# Altium schematic sheet Schematic.SchDoc(Schematic)
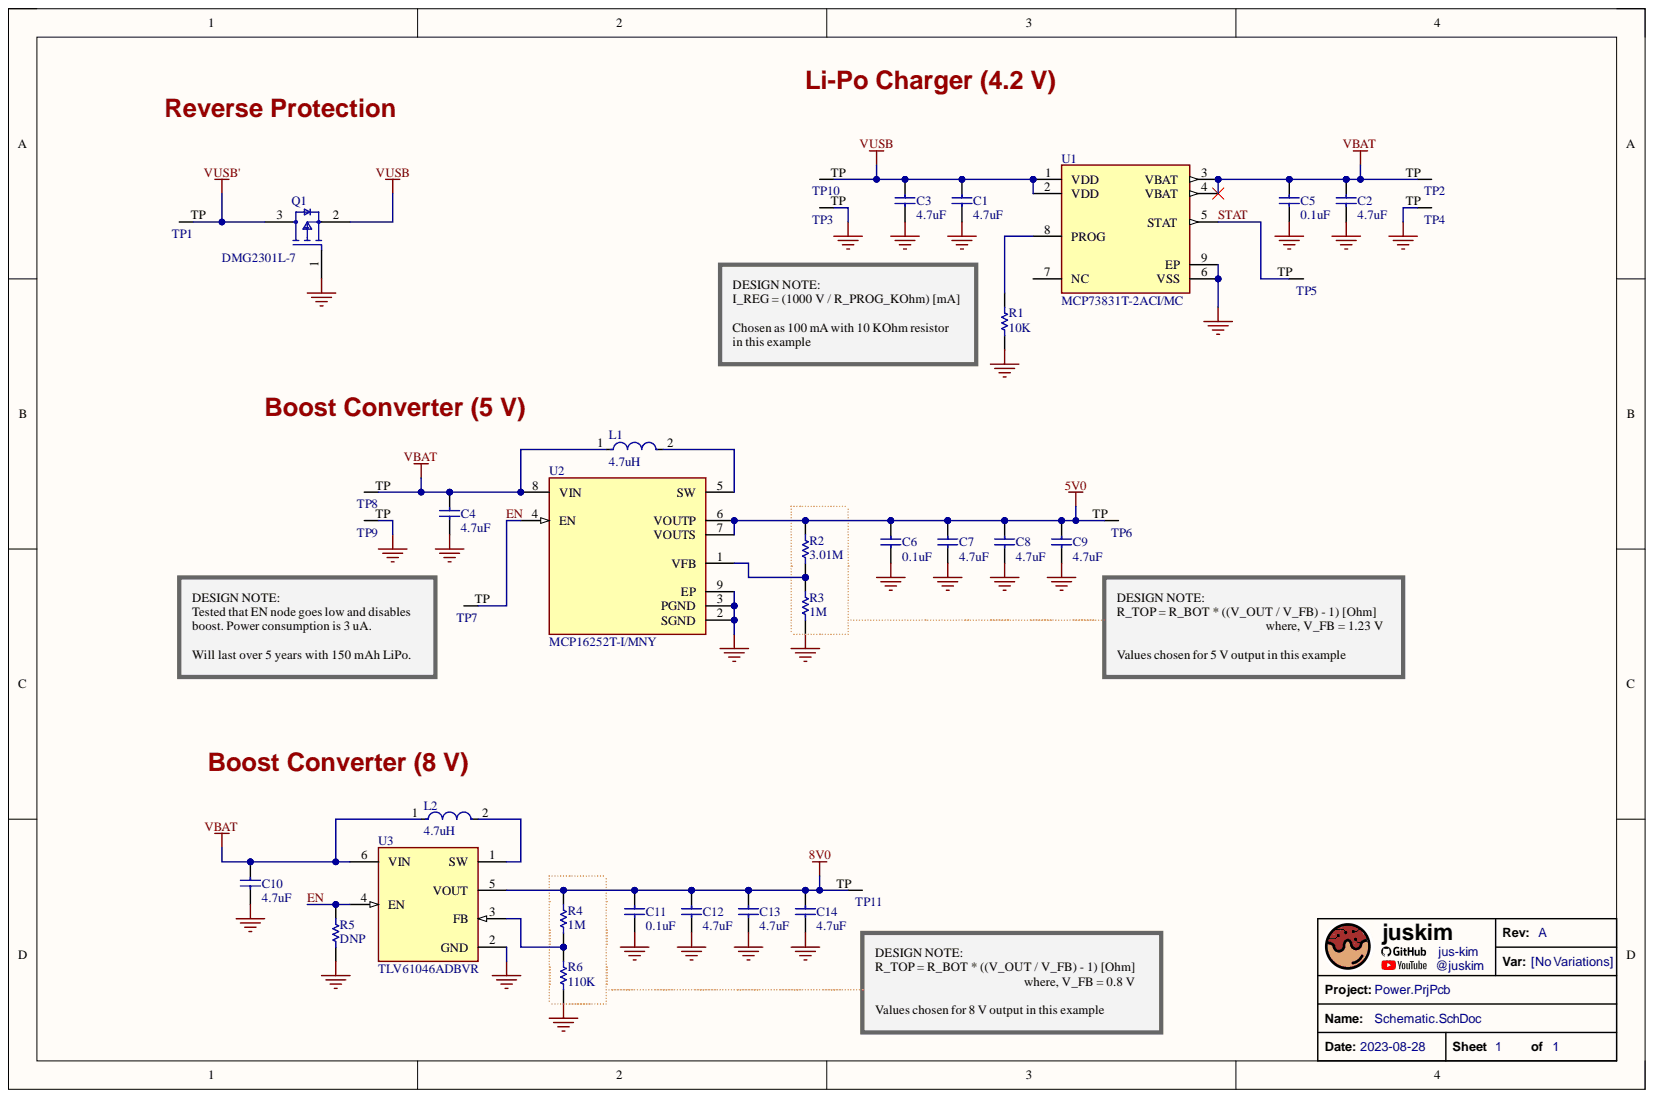
[diagram p0: Schematic.SchDoc]

## Parts
1  TitleBlock_juskim  (value not drawn)
C1  value 4.7uF  [0402]  (hidden comment: CC0402MRX5R6BB475)
C2  value 4.7uF  [0402]  (hidden comment: CC0402MRX5R6BB475)
C3  value 4.7uF  [0402]  (hidden comment: CC0402MRX5R6BB475)
C4  value 4.7uF  [0402]  (hidden comment: CC0402MRX5R6BB475)
C5  value 0.1uF  [0201]  (hidden comment: C0603X5R1C104K030BC)
C6  value 0.1uF  [0201]  (hidden comment: C0603X5R1C104K030BC)
C7  value 4.7uF  [0402]  (hidden comment: CC0402MRX5R6BB475)
C8  value 4.7uF  [0402]  (hidden comment: CC0402MRX5R6BB475)
C9  value 4.7uF  [0402]  (hidden comment: CC0402MRX5R6BB475)
C10  value 4.7uF  [0402]  (hidden comment: CC0402MRX5R6BB475)
C11  value 0.1uF  [0201]  (hidden comment: C0603X5R1C104K030BC)
C12  value 4.7uF  [0402]  (hidden comment: CC0402MRX5R6BB475)
C13  value 4.7uF  [0402]  (hidden comment: CC0402MRX5R6BB475)
C14  value 4.7uF  [0402]  (hidden comment: CC0402MRX5R6BB475)
L1  value 4.7uH  [806]  (hidden comment: DFE201610E-4R7M=P2)
L2  value 4.7uH  [806]  (hidden comment: DFE201610E-4R7M=P2)
Q1  DMG2301L-7  [FP-SOT23-MFG]
R1  value 10K  [RES0201]  (hidden comment: AC0201FR-0710KL)
R2  value 3.01M  [RES0201]  (hidden comment: CRCW02013M01FNED)
R3  value 1M  [RES0201]  (hidden comment: RC0201FR-071ML)
R4  value 1M  [RES0201]  (hidden comment: RC0201FR-071ML)
R5  value DNP  [RES0201]
R6  value 110K  [RES0201]  (hidden comment: RC0201FR-07110KL)
TP1  Testpoint_1mm  [Testpoint_1mm]  (value not drawn)
TP2  Testpoint_1mm  [Testpoint_1mm]  (value not drawn)
TP3  Testpoint_1mm  [Testpoint_1mm]  (value not drawn)
TP4  Testpoint_1mm  [Testpoint_1mm]  (value not drawn)
TP5  Testpoint_1mm  [Testpoint_1mm]  (value not drawn)
TP6  Testpoint_1mm  [Testpoint_1mm]  (value not drawn)
TP7  Testpoint_1mm  [Testpoint_1mm]  (value not drawn)
TP8  Testpoint_1mm  [Testpoint_1mm]  (value not drawn)
TP9  Testpoint_1mm  [Testpoint_1mm]  (value not drawn)
TP10  Testpoint_1mm  [Testpoint_1mm]  (value not drawn)
TP11  Testpoint_1mm  [Testpoint_1mm]  (value not drawn)
U1  MCP73831T-2ACI/MC  [8-DFN]
U2  MCP16252T-I/MNY  [8-TDFN]
U3  TLV61046ADBVR  [FP-DBV0006A-MFG]

## Nets
5V0: C6.1 C7.1 C8.1 C9.1 R2.2 TP6.TP U2.6(VOUTP) U2.7(VOUTS)
8V0: C11.1 C12.1 C13.1 C14.1 R4.2 TP11.TP U3.5(VOUT)
GND: C1.2 C2.2 C3.2 C4.2 C5.2 C6.2 C7.2 C8.2 C9.2 C10.2 C11.2 C12.2 C13.2 C14.2 Q1.1 R1.1 R3.1 R5.1 R6.1 TP3.TP TP4.TP TP9.TP U1.6(VSS) U1.9(EP) U2.2(SGND) U2.3(PGND) U2.9(EP) U3.2(GND)
VBAT: C2.1 C4.1 C5.1 C10.1 L1.1 L2.1 TP2.TP TP8.TP U1.3(VBAT) U1.4(VBAT) U2.8(VIN) U3.6(VIN)
VUSB: C1.1 C3.1 Q1.2 TP10.TP U1.1(VDD) U1.2(VDD)
VUSB': Q1.3 TP1.TP
EN: R5.2 TP7.TP U2.4(EN) U3.4(EN)
STAT: TP5.TP U1.5(STAT)
NetL1_2: L1.2 U2.5(SW)
NetL2_2: L2.2 U3.1(SW)
NetR1_2: R1.2 U1.8(PROG)
NetR2_1: R2.1 R3.2 U2.1(VFB)
NetR4_1: R4.1 R6.2 U3.3(FB)

Not connected: U1 7(NC)

## Library data of the parts
C1-C4 C7-C10 C12-C14 = C0402-1µ0: 4.7 µF ±20% 10V Ceramic Capacitor X5R 0402 (1005 Metric); Manufacturer: YAGEO; Database Table Name: Capacitors$; Component Kind: Standard; Library Name: Nordic Passive DB.DbLib; Link - DigiKey: https://www.digikey.ca/en/products/detail/yageo/CC0402MRX5R6BB475/5195143; Link - Mouser: https://www.mouser.ca/ProductDetail/YAGEO/CC0402MRX5R6BB475?qs=shS2SvXCj6LN65weGRgEbQ%3D%3D; Pin Count: 2
C5 C6 C11 = C0402-1µ0: 0.1 µF ±10% 16V Ceramic Capacitor X5R 0201 (0603 Metric); Manufacturer: TDK Corporation; Database Table Name: Capacitors$; Component Kind: Standard; Library Name: Nordic Passive DB.DbLib; Link - DigiKey: https://www.digikey.ca/en/products/detail/tdk-corporation/C0603X5R1C104K030BC/2732748; Link - Mouser: https://www.mouser.ca/ProductDetail/TDK/C0603X5R1C104K030BC?qs=iuKcRwBk4Q%252BCmpTym6phKQ%3D%3D; Pin Count: 2
L1 L2 = CMP-06042-006199-1: Shielded Wirewound Inductor 4.7uH 20% 1100mA 0.288Ω 0806 (2016); Manufacturer: Murata Electronics; Component Kind: Standard; Library Name: Altium Content Vault; Link - DigiKey: https://www.digikey.ca/en/products/detail/murata-electronics/DFE201610E-4R7M-P2/7927533; Link - Mouser: https://www.mouser.ca/ProductDetail/Murata-Electronics/DFE201610E-4R7M%3dP2?qs=AQlKX63v8RuIglbQNBhlhg%3D%3D; Pin Count: 2
Q1 = CMP-12801-000065-1: MOSFET P-CH 20V 3A SOT23; Manufacturer: Diodes Inc.; Continuous Drain Current: -3A; Depletion Mode: False; Drain To Source Breakdown Voltage: -20V; Drain To Source Resistance: 120mΩ; Gate Charge At Vgs: 5.5nC @ -4.5V; Gate To Source Voltage: 8V; Input Capacitace At Vds: 476pF @ -10V; Max Forward Diode Voltage: -1.2V; Max Junction Temp: +150°C; Number Of Channels: 1; Power Dissipation: 1.5W; Pulse Drain Current: -10A; Rthja Max: 83°C/W; Standoff Height: 0.013mm; Threshold Vgs Min: -0.4V; Turn Off Delay Time: 30ns; Turn On Delay Time: 5ns; Automotive: No; Category: Trans; Component Kind: Standard; ComponentLink2Description: Datasheet; ComponentLink2URL: https://www.diodes.com/assets/Datasheets/DMG2301L.pdf; Device Class L1: Discrete Semiconductors; Device Class L2: Transistors; Device Class L3: MOSFETs; Digikey Description: MOSFET P-CH 20V 3A SOT23; Drain To Source Voltage: -20V; Footprint Url: https://www.diodes.com/assets/Package-Files/SOT23.pdf; Height: 1.1mm; Lead Free: Yes; Library Name: Altium Content Vault; Link - DigiKey: https://www.digikey.ca/en/products/detail/diodes-incorporated/DMG2301L-7/5768820; Link - Mouser: https://www.mouser.ca/ProductDetail/Diodes-Incorporated/DMG2301L-7?qs=60RJRzIpcl8mqpUzCaZuxQ%3D%3D; Manufacturer Part Number: DMG2301L-7; Mouser Description: Single P-Channel 20 V 1.5 W 5.5 nC Silicon Surface Mount Mosfet - SOT-23; Number Of P Channels: 1; Octopart: https://octopart.com/dmg2301l-7-diodes+inc.-67250456; Package: SOT23; RoHS: TRUE; Temperature: -55°C to +150°C; Temperature Range High: +150°C; Temperature Range Low: -55°C; Threshold Vgs Max: -1.2V
R1 = RC0603JR-07330RL: 10 kOhms ±1% 0.05W, 1/20W Chip Resistor 0201 (0603 Metric) Automotive AEC-Q200, Moisture Resistant Thick Film; Manufacturer: YAGEO; Component Kind: Standard; Library Name: Resistors.SchLib; Link - DigiKey: https://www.digikey.ca/en/products/detail/yageo/AC0201FR-0710KL/5894228; Link - Mouser: https://www.mouser.ca/ProductDetail/YAGEO/AC0201FR-0710KL?qs=NgbZBzc1CyFiKufBuYG%252Bxg%3D%3D; Pin Count: 2
R2 = RC0603JR-07330RL: 3.01 MOhms ±1% 0.05W, 1/20W Chip Resistor 0201 (0603 Metric) Thick Film; Manufacturer: Vishay Dale; Component Kind: Standard; Library Name: Resistors.SchLib; Link - DigiKey: https://www.digikey.ca/en/products/detail/vishay-dale/CRCW02013M01FNED/5069823; Link - Mouser: https://www.mouser.ca/ProductDetail/Vishay-Dale/CRCW02013M01FNED?qs=wt73SADzcbOm3q3vf8VDkQ%3D%3D; Pin Count: 2
R3 R4 = RC0603JR-07330RL: 1 MOhms ±1% 0.05W, 1/20W Chip Resistor 0201 (0603 Metric) Moisture Resistant Thick Film; Manufacturer: YAGEO; Component Kind: Standard; Library Name: Resistors.SchLib; Link - DigiKey: https://www.digikey.ca/en/products/detail/yageo/RC0201FR-071ML/3202390; Link - Mouser: https://www.mouser.ca/ProductDetail/YAGEO/RC0201FR-071ML?qs=sGAEpiMZZMukHu%252BjC5l7YU5x11BP1OalgSBPhfNS1uM%3D; Pin Count: 2
R5 = RC0603JR-07330RL: DNP; Component Kind: Standard; Library Name: Resistors.SchLib; Pin Count: 2
R6 = RC0603JR-07330RL: 110 kOhms ±1% 0.05W, 1/20W Chip Resistor 0201 (0603 Metric) Moisture Resistant Thick Film; Manufacturer: YAGEO; Component Kind: Standard; Library Name: Resistors.SchLib; Link - DigiKey: https://www.digikey.ca/en/products/detail/yageo/RC0201FR-07110KL/5280238; Link - Mouser: https://www.mouser.ca/ProductDetail/YAGEO/RC0201FR-07110KL?qs=Q4gDqC5t5%2FAYMQb655YGSA%3D%3D; Pin Count: 2
U1 = CMP-0133-00070-2: IC CONTROLLER LI-ION 4.2V 8DFN; Max Input Voltage: 7V; Max Supply Voltage: 6V; Min Supply Voltage: 3.75V; Number of Channels: 1; Operating Supply Current: 510uA; Output Current: 500mA; Case/Package: DFN; Component Kind: Standard; Contact Plating: Tin; Lead Free: Lead Free; Library Name: Altium Content Vault; Link - DigiKey: https://www.digikey.ca/en/products/detail/microchip-technology/MCP73831T-2ACI-MC/964300?s=N4IgTCBcDaILIGEAKB2AzADjQRgCoFowBBBASQHpEQBdAXyA; Link - Mouser: https://www.mouser.ca/ProductDetail/Microchip-Technology/MCP73831T-2ACI-MC?qs=yUQqVecv4quJ3ICqm%2FGZxw%3D%3D; Max Operating Temperature: 85°C; Min Operating Temperature: -40°C; Mount: Surface Mount; Number of Pins: 8; Output Voltage: 4.2V; Package Quantity: 3300; Packaging: Tape and Reel; Radiation Hardening: No
U2 = CMP-06325-000053-1: IC REG BOOST ADJ 100MA 8TDFN; Efficiency: 96%; Max Input Voltage: 5.5V; Min Input Voltage: 350mV; Min Output Voltage: 1.8V; Number of Outputs: 1; Output Configuration: Positive; Output Type: Adjustable; Switching Frequency: 575kHz; Synchronous Rectifier: Yes; Component Kind: Standard; Lead Free: Lead Free; Library Name: Altium Content Vault; Link - DigiKey: https://www.digikey.ca/en/products/detail/microchip-technology/MCP16252T-I-MNY/4079880?s=N4IgTCBcDaILIGEAKBGAbGArGAKgWgEkB6OAOQE0QBdAXyA; Link - Mouser: https://www.mouser.ca/ProductDetail/Microchip-Technology/MCP16252T-I-MNY?qs=6qKXPk0vzx3BzvIEz9edbg%3D%3D; Max Operating Temperature: 85°C; Max Output Voltage: 5.5V; Min Operating Temperature: -40°C; Mount: Surface Mount; Number of Pins: 8; Output Current: 100mA; Output Voltage: 5.5V; Package Quantity: 3300; Packaging: Tape and Reel; Radiation Hardening: No; RoHS Compliant: Yes; Series: MCP16251/2; Topology: Boost
U3 = CMP-04918-000051-1: Boost Switching Regulator IC Positive Adjustable 3.3V 1 Output SOT-23-6; Manufacturer: Texas Instruments; Max Junction Temp: +125°C; Max Supply Voltage: 5.5V; Min Supply Voltage: 1.8V; Nominal Supply Current: 110uA; Number Of Outputs: 1; Output Current: 980mA; Output Type: AdjustableProgrammable; Output Voltage: 3.3-28V; Standoff Height: 0mm; Switching Frequency: 1050kHz; Automotive: No; Category: IC; Component Kind: Standard; ComponentLink2Description: Datasheet; ComponentLink2URL: http://www.ti.com/lit/ds/symlink/tlv61046a.pdf; Device Class L1: Integrated Circuits (ICs); Device Class L2: Power Management ICs; Device Class L3: Voltage Regulators - Switching; Digikey Description: IC REG BOOST ADJ SOT23-6; Height: 1.45mm; Ipc Land Pattern Name: SOT95P280X145-6; Lead Free: Yes; Library Name: Altium Content Vault; Link - DigiKey: https://www.digikey.ca/en/products/detail/texas-instruments/TLV61046ADBVR/8133008; Link - Mouser: https://www.mouser.ca/ProductDetail/Texas-Instruments/TLV61046ADBVR?qs=5aG0NVq1C4xWWIjQ%252BcBpXg%3D%3D; Manufacturer Part Number: TLV61046ADBVR; Mouser Description: 28-V Output Voltage Boost Converter with Power Diode and Isolation Switch 6-SOT-23 -40 to 125; Octopart: https://octopart.com/tlv61046adbvr-texas+instruments-81856326; Package: DBV0006A; RoHS: TRUE; Switching Topology: Boost; Temperature: -40°C to +125°C; Temperature Range High: +125°C; Temperature Range Low: -40°C
1 = TitleBlock_juskim: Component Kind: Standard; Library Name: juskim_SchLib.SchLib
TP1-TP11 = Testpoint_1mm: Component Kind: Standard; Library Name: MySchematics.SchLib
